# Altium schematic sheet Sensors-2.SchDoc(Sensors-2)
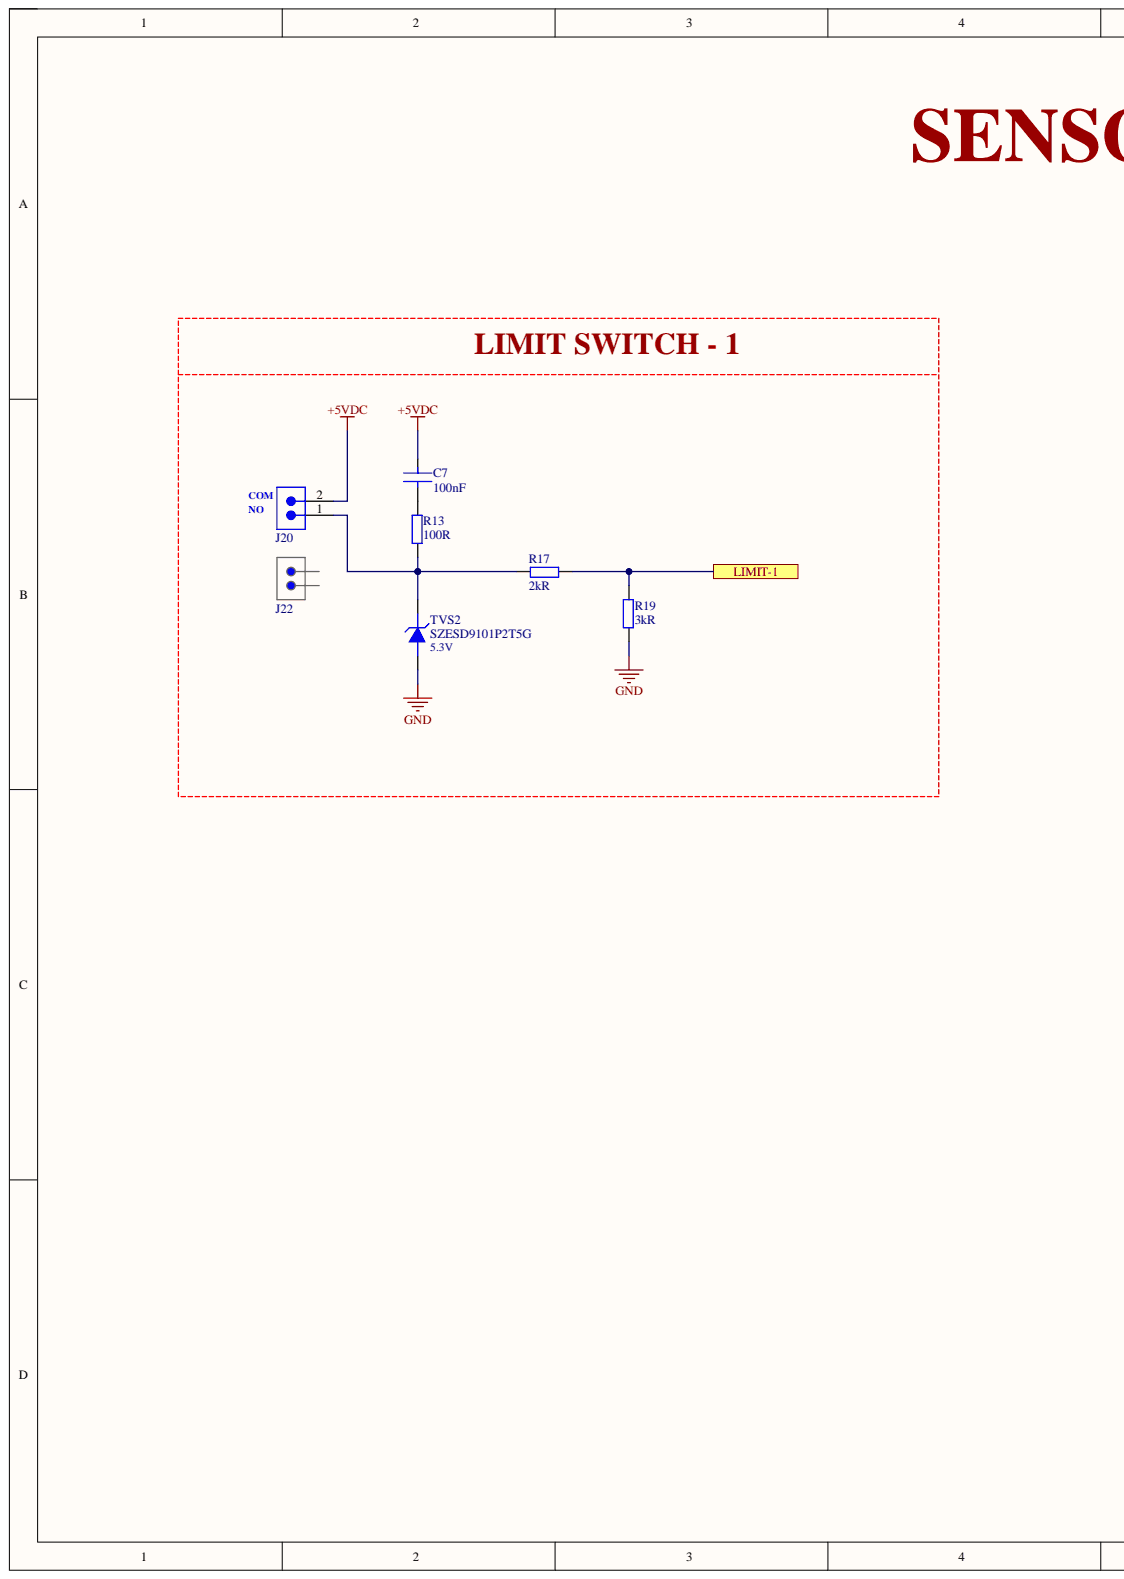
[diagram p0: Sensors-2.SchDoc, left]
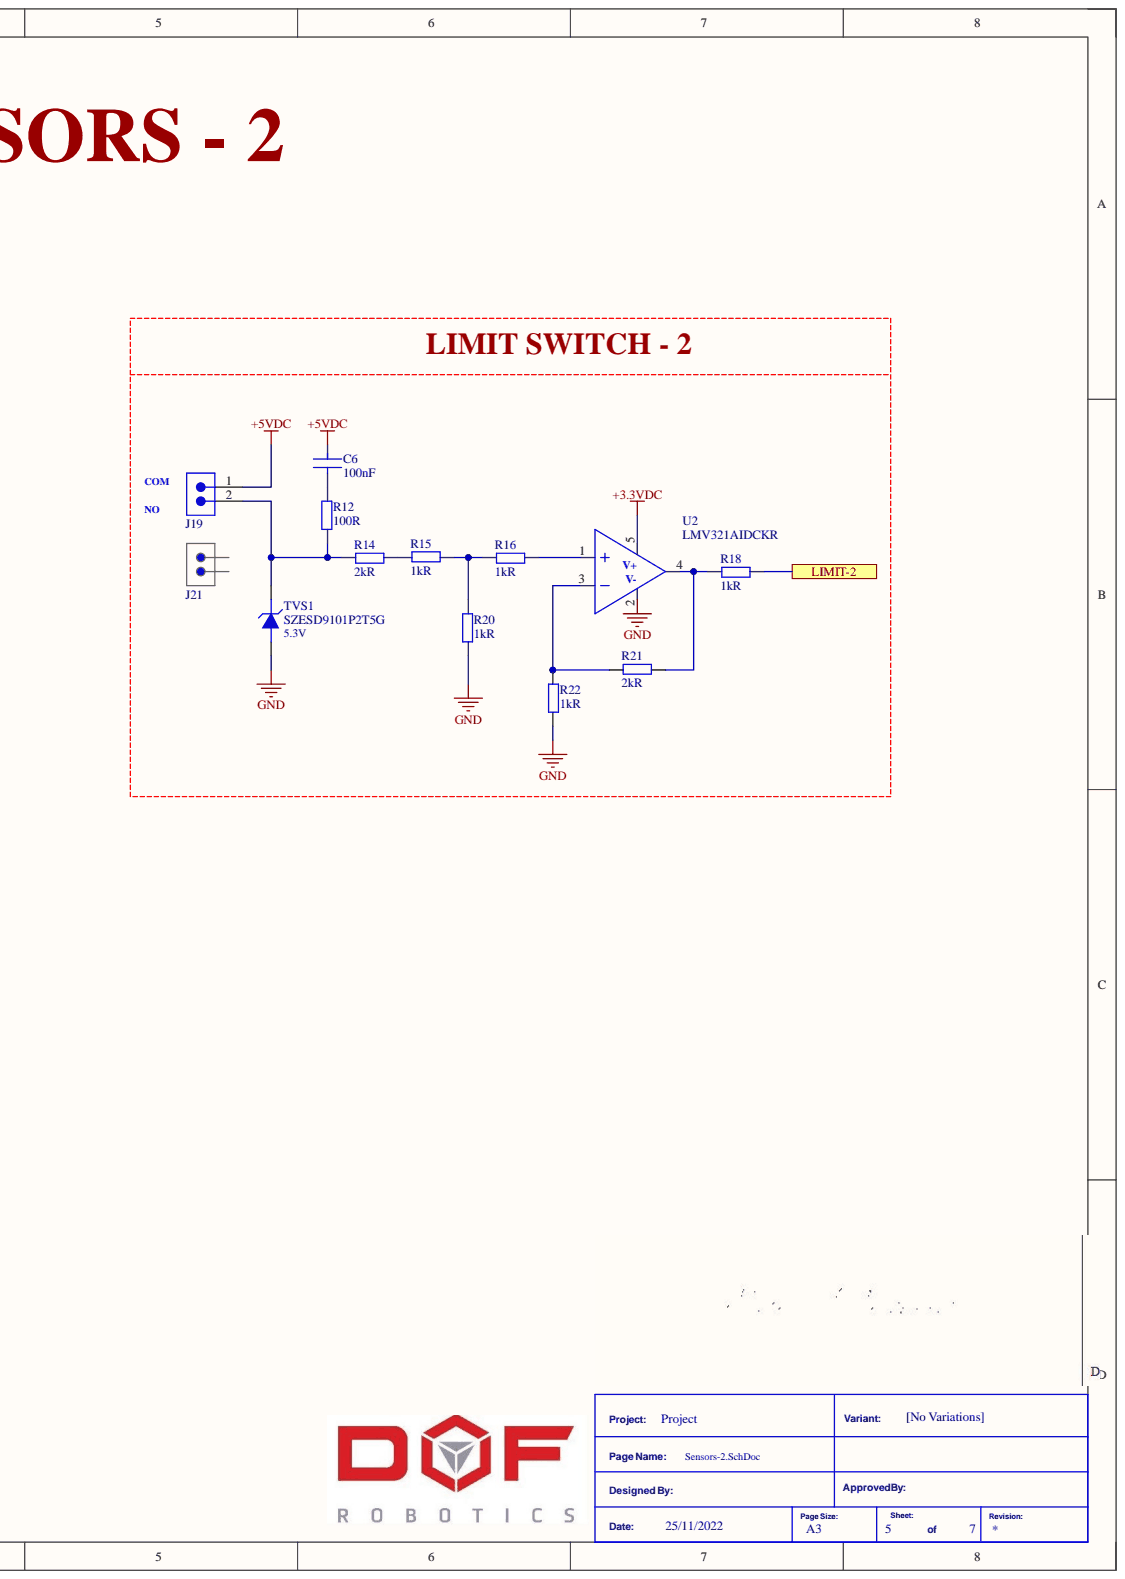
[diagram p1: Sensors-2.SchDoc, right]

## Parts
C6  Capacitance: 100nF  [CAP CER 0805]  (hidden comment: CL21B104KACNNNC)
C7  Capacitance: 100nF  [CAP CER 0805]  (hidden comment: CL21B104KACNNNC)
J19  691313710002  [CONN ML 1X2 WURTH 691313710002]  (value not drawn)
J20  691313710002  [CONN ML 1X2 WURTH 691313710002]  (value not drawn)
J21  691352710002  [CONN FML 1X2 WURTH 691352710002]  (value not drawn)
J22  691352710002  [CONN FML 1X2 WURTH 691352710002]  (value not drawn)
R12  Resistance: 100R  [RES 0805]  (hidden comment: ERJ-P06J101V)
R13  Resistance: 100R  [RES 0805]  (hidden comment: ERJ-P06J101V)
R14  Resistance: 2kR  [RES 0603]  (hidden comment: ERJ-3EKF2001V)
R15  Resistance: 1kR  [RES 0603]  (hidden comment: ERJ-PA3J102V)
R16  Resistance: 1kR  [RES 0603]  (hidden comment: ERJ-PA3J102V)
R17  Resistance: 2kR  [RES 0603]  (hidden comment: ERJ-3EKF2001V)
R18  Resistance: 1kR  [RES 0603]  (hidden comment: ERJ-PA3J102V)
R19  Resistance: 3kR  [RES 0603]  (hidden comment: AC0603FR-073KL)
R20  Resistance: 1kR  [RES 0603]  (hidden comment: ERJ-PA3J102V)
R21  Resistance: 2kR  [RES 0603]  (hidden comment: ERJ-3EKF2001V)
R22  Resistance: 1kR  [RES 0603]  (hidden comment: ERJ-PA3J102V)
TVS1  SZESD9101P2T5G  Min Breakdown Voltage: 5.3V  [SOD-923]
TVS2  SZESD9101P2T5G  Min Breakdown Voltage: 5.3V  [SOD-923]
U2  LMV321AIDCKR  [SC-70-5/SOT-353]

## Ports
LIMIT-1, LIMIT-2

## Nets
+3.3VDC: U2.5
+5VDC: C6.2 C7.2 J19.1 J20.2
GND: R19.1 R20.1 R22.2 TVS1.1 TVS2.1 U2.2
LIMIT-1: R17.1 R19.2 ; port LIMIT-1
LIMIT-2: R18.1 ; port LIMIT-2
NetC6_1: C6.1 R12.2
NetC7_1: C7.1 R13.2
NetJ19_2: J19.2 R12.1 R14.2 TVS1.2
NetJ20_1: J20.1 R13.1 R17.2 TVS2.2
NetR14_1: R14.1 R15.1
NetR15_2: R15.2 R16.2 R20.2
NetR16_1: R16.1 U2.1
NetR18_2: R18.2 R21.2 U2.4
NetR21_1: R21.1 R22.1 U2.3

## Library data of the parts
C6 C7 = CAP CER 0.1UF 25V X7R 0805: Manufacturer: Samsung; Tolerance: 10%; Case Code (Imperial): 0805; Case Code (Metric): 2012; Case/Package: 0805; Category: Ceramic Capacitors; Component Kind: Standard; ComponentLink1Description: https://datasheet.ciiva.com/25801/cl21b104kacnnnc-25801824.pdf?src-supplier=Digi-Key; ComponentLink1URL: https://datasheet.ciiva.com/25801/cl21b104kacnnnc-25801824.pdf?src-supplier=Digi-Key; ComponentLink2Description: https://datasheet.ciiva.com/15216/getdatasheetpartid-355204-15216236.pdf?src-supplier=Verical; ComponentLink2URL: https://datasheet.ciiva.com/15216/getdatasheetpartid-355204-15216236.pdf?src-supplier=Verical; Construction: Flat; Contact Plating: Tin; Depth: 1.25mm; Description: CAP CER 0.1UF 25V X7R 0805; Dielectric: X7R; Height: 0.85mm; Length: 2mm; Manufacturer Part Number: CL21B104KACNNNC; Max Operating Temperature: 125°C; Min Operating Temperature: -55°C; Mount: Surface Mount; Package Quantity: 1; Packaging: Tape and Reel; Pricing 1: 1=0.10000, 10=0.04000, 50=0.02200, 100=0.01790, 500=0.01282, 1000=0.01007 (USD); Radiation Hardening: No; RoHS: Yes; RoHS Compliant: Yes; Series: CL; Stock 1: 2617; Supplier 1: Digi-Key; Supplier Part Number 1: 1276-1099-1-ND; Thickness: 0.037inch; Voltage: 25V; Voltage Rating: 25V; Width: 0.049inch; Library Name: LibEnes.SchLib
J19 J20 = CONN ML 1X2 WURTH 691313710002: 5mm Pitch Right Angle Pluggable Term Block; PCB Header; Through Hole; 2 Way  (Mate to 691352710002); Manufacturer: Wurth Electronics; Connector Type: PCB,Terminal Block; Current: 20A; Number of Contacts: 2; Number of Levels: 1; Pitch: 0.197inch; Voltage: 300V; Category: Terminal Blocks; Color: Green; Component Kind: Standard; ComponentLink1Description: https://datasheet.ciiva.com/795/6913137100xx-795778.pdf?src-supplier=Digi-Key; ComponentLink1URL: https://datasheet.ciiva.com/795/6913137100xx-795778.pdf?src-supplier=Digi-Key; ComponentLink2Description: https://datasheet.ciiva.com/8043/1442229-8043531.pdf?src-supplier=Element14; ComponentLink2URL: https://datasheet.ciiva.com/8043/1442229-8043531.pdf?src-supplier=Element14; ComponentLink3Description: https://datasheet.ciiva.com/48692/001088691-da-01-en-wr-tbl-terminal-block3137-691313710002-48692902.pdf?src-supplier=Conrad; ComponentLink3URL: https://datasheet.ciiva.com/48692/001088691-da-01-en-wr-tbl-terminal-block3137-691313710002-48692902.pdf?src-supplier=Conrad; Contact Material: Brass; Height: 12mm; Length: 12.5mm; Manufacturer Part Number: 691313710002; Mount: Through Hole; Number of Positions Per Level: 2; Number of Rows: 1; Package Quantity: 600; Packaging: Bulk; Pricing 1: 1=0.44000, 10=0.39400, 50=0.34000, 100=0.30500, 250=0.28600, 600=0.26900 (USD); REACH SVHC: Unknown; RoHS: Yes; RoHS Compliant: Yes; Series: WR-TBL Series 3137; Stock 1: 2898; Supplier 1: Digi-Key; Supplier Part Number 1: 732-2799-ND; Termination: Solder; Width: 12mm; Library Name: LibEnes.SchLib
J21 J22 = CONN FML 1X2 WURTH 691352710002: Conn Terminal Block F 2 POS 5mm Screw RA Cable Mount 20A Box    (Mate to 691313710002); Manufacturer: Wurth Electronics; Current Rating: 12A; Number of Contacts: 2; Number of Levels: 1; Pitch: 0.197inch; Stripping Length: -7mm; Voltage: 300V; Wire Gauge (Max): 24AWG; Wire Gauge (Min): 12AWG; Category: Terminal Blocks; Color: Green; Component Kind: Standard; ComponentLink1Description: https://datasheet.ciiva.com/7992/1442233-7992379.pdf?src-supplier=Element14; ComponentLink1URL: https://datasheet.ciiva.com/7992/1442233-7992379.pdf?src-supplier=Element14; ComponentLink2Description: https://datasheet.ciiva.com/796/6913527100xx-796063.pdf?src-supplier=Digi-Key; ComponentLink2URL: https://datasheet.ciiva.com/796/6913527100xx-796063.pdf?src-supplier=Digi-Key; Contact Material: Brass; Current: 20A; Lead Free: Lead Free; Length: 18.2mm; Manufacturer Part Number: 691352710002; Mount: Free Hanging; Number of Positions Per Level: 2; Package Quantity: 350; Packaging: Bulk; REACH SVHC: Unknown; RoHS: Yes; RoHS Compliant: Yes; Series: WR-TBL Series 3527; Stock 1: 2782; Supplier 1: Digi-Key; Supplier Part Number 1: 732-2775-ND; Supplier Unit Price 1: 1=1.32000, 10=1.18200, 50=1.02200, 100=0.91400, 350=0.85900, 700=0.80500 (USD); Termination: Screw; Voltage Rating: 300V; Width: 10mm; Wire/Cable Gauge: 24AWG; Library Name: LibEnes.SchLib
R12 R13 = RES 100R 5% 0.5W 0805: Res Thick Film 0805 100 Ohm 5% 0.5W ±200ppm/°C Molded SMD Punched Carrier T/R; Manufacturer: Panasonic; Max Power Dissipation: 500mW; Voltage Rating: 400V; Voltage Rating (DC): 150V; Case Code (Imperial): 0805; Case Code (Metric): 2012; Case/Package: 0805; Category: Chip SMD Resistors; Component Kind: Standard; ComponentLink1Description: https://datasheet.ciiva.com/11810/0900766b812cb812-11810156.pdf?src-supplier=RSComponents; ComponentLink1URL: https://datasheet.ciiva.com/11810/0900766b812cb812-11810156.pdf?src-supplier=RSComponents; ComponentLink2Description: https://datasheet.ciiva.com/7831/aoa0000ce4-7831000.pdf?src-supplier=Element14; ComponentLink2URL: https://datasheet.ciiva.com/7831/aoa0000ce4-7831000.pdf?src-supplier=Element14; ComponentLink3Description: https://datasheet.ciiva.com/24163/aoa0000ce4-64075-24163318.pdf?src-supplier=Mouser; ComponentLink3URL: https://datasheet.ciiva.com/24163/aoa0000ce4-64075-24163318.pdf?src-supplier=Mouser; ComponentLink4Description: https://datasheet.ciiva.com/16788/70068906-16788678.pdf?src-supplier=Allied+Electronics; ComponentLink4URL: https://datasheet.ciiva.com/16788/70068906-16788678.pdf?src-supplier=Allied+Electronics; ComponentLink5Description: https://datasheet.ciiva.com/16767/70068906-16767564.pdf?src-supplier=Allied+Electronics; ComponentLink5URL: https://datasheet.ciiva.com/16767/70068906-16767564.pdf?src-supplier=Allied+Electronics; ComponentLink6Description: https://datasheet.ciiva.com/9186/aoa0000ce4-9186844.pdf?src-supplier=Avnet; ComponentLink6URL: https://datasheet.ciiva.com/9186/aoa0000ce4-9186844.pdf?src-supplier=Avnet; Construction: Rectangular; Contact Plating: Tin; Depth: 1.25mm; Features: Automotive AEC-Q200; Height: 0.6mm; Lead Free: Lead Free; Length: 2mm; Manufacturer Part Number: ERJ-P06J101V; Max Operating Temperature: 155°C; Military Standard: Not; Min Operating Temperature: -55°C; Mount: Surface Mount; Number of Terminations: 2; Package Quantity: 1; Packaging: Tape and Reel; Power Rating: 500mW; Pricing 1: 1=0.12000, 10=0.10600, 100=0.04070, 1000=0.01790, 2500=0.01546 (USD); REACH SVHC: Unknown; Resistance Tolerance: 5%; Resistor Type: Thick Film; RoHS: Yes; RoHS Compliant: Yes; Series: ERJ; Stock 1: 27836; Supplier 1: Digi-Key; Supplier Part Number 1: P100ADCT-ND; Technology: THICK FILM; Temperature Coefficient: 200ppm/°C; Termination: SMD/SMT; Tolerance: 5%; Weight: 0.000194oz; Width: 1.2mm; Library Name: LibEnes.SchLib
R14 R17 R21 = RES 2KR 1% 0.1W 0603: Res Thick Film 0603 2K Ohm 1% 1/10W ±100ppm/°C Molded SMD Punched Carrier T/R; Manufacturer: Panasonic; Max Power Dissipation: 100mW; Voltage Rating: 75V; Voltage Rating (DC): 50V; Case Code (Imperial): 0603; Case Code (Metric): 1608; Case/Package: 0603; Category: Chip SMD Resistors; Component Kind: Standard; ComponentLink1Description: https://datasheet.ciiva.com/43460/1678782-43460485.pdf?src-supplier=Farnell; ComponentLink1URL: https://datasheet.ciiva.com/43460/1678782-43460485.pdf?src-supplier=Farnell; ComponentLink2Description: https://datasheet.ciiva.com/6082/1678782-6082059.pdf?src-supplier=Farnell; ComponentLink2URL: https://datasheet.ciiva.com/6082/1678782-6082059.pdf?src-supplier=Farnell; ComponentLink3Description: https://datasheet.ciiva.com/46377/1678782-46377366.pdf?src-supplier=Element14; ComponentLink3URL: https://datasheet.ciiva.com/46377/1678782-46377366.pdf?src-supplier=Element14; ComponentLink4Description: https://datasheet.ciiva.com/28673/1678782-28673990.pdf?src-supplier=Element14; ComponentLink4URL: https://datasheet.ciiva.com/28673/1678782-28673990.pdf?src-supplier=Element14; ComponentLink5Description: https://datasheet.ciiva.com/24027/aoa0000ce2-24027453.pdf?src-supplier=Mouser; ComponentLink5URL: https://datasheet.ciiva.com/24027/aoa0000ce2-24027453.pdf?src-supplier=Mouser; ComponentLink6Description: https://datasheet.ciiva.com/16771/70260748-16771369.pdf?src-supplier=Allied+Electronics; ComponentLink6URL: https://datasheet.ciiva.com/16771/70260748-16771369.pdf?src-supplier=Allied+Electronics; ComponentLink7Description: https://datasheet.ciiva.com/16200/aoa0000ce2-16200501.pdf?src-supplier=Future+Electronics; ComponentLink7URL: https://datasheet.ciiva.com/16200/aoa0000ce2-16200501.pdf?src-supplier=Future+Electronics; ComponentLink8Description: https://datasheet.ciiva.com/30064/aoa0000ce2-30064920.pdf?src-supplier=Onlinecomponents.com; ComponentLink8URL: https://datasheet.ciiva.com/30064/aoa0000ce2-30064920.pdf?src-supplier=Onlinecomponents.com; Construction: Rectangular; Contact Plating: Tin; Depth: 0.8mm; Features: Automotive AEC-Q200; Height: 0.022inch; Lead Free: Lead Free; Length: 1.6mm; Manufacturer Part Number: ERJ-3EKF2001V; Max Operating Temperature: 155°C; Military Standard: Not; Min Operating Temperature: -55°C; Mount: Surface Mount; Number of Terminations: 2; Package Quantity: 1; Packaging: Tape and Reel; Power Rating: 100mW; Pricing 1: 1=0.10000, 10=0.04800, 100=0.01930, 1000=0.00868, 2500=0.00754 (USD); Radiation Hardening: No; REACH SVHC: No SVHC; Resistance Tolerance: 1%; Resistor Type: Thick Film; RoHS: Yes; RoHS Compliant: Yes; Series: ERJ; Size Code: 0603; Stock 1: 1368069; Supplier 1: Digi-Key; Supplier Part Number 1: P2.00KHCT-ND; Temperature Coefficient: 100ppm/°C; Termination: SMD/SMT; Tolerance: 1%; Width: 0.031inch; Library Name: LibEnes.SchLib
R15 R16 R18 R20 R22 = RES 1KR 5% 0.25W 0603: Res Thick Film 0603 1K Ohm 5% 0.25W(1/4W) ±200ppm/C Pad SMD Automotive T/R; Manufacturer: Panasonic; Max Power Dissipation: 250mW; Temperature Coefficient: 200ppm/°C; Case Code (Imperial): 0603; Case Code (Metric): 1608; Case/Package: 0603; Category: Chip SMD Resistors; Component Kind: Standard; ComponentLink1Description: https://industrial.panasonic.com/ww/system/files/data/download/catalog/id_fixed-resistors_e_1.pdf?src-supplier=Digi-Key; ComponentLink1URL: https://industrial.panasonic.com/ww/system/files/data/download/catalog/id_fixed-resistors_e_1.pdf?src-supplier=Digi-Key; Features: Automotive AEC-Q200; Height: 0.018inch; Length: 0.063inch; Manufacturer Part Number: ERJ-PA3J102V; Max Operating Temperature: 155°C; Min Operating Temperature: -55°C; Number of Terminations: 2; Packaging: Tape and Reel; Pricing 1: 1=0.11000, 10=0.09600, 100=0.03710, 1000=0.01631, 2500=0.01408 (USD); Resistor Type: Thick Film; RoHS: Yes; Stock 1: 25628; Supplier 1: Digi-Key; Supplier Part Number 1: P1KBZCT-ND; Tolerance: 5%; Width: 0.031inch; Library Name: LibEnes.SchLib
R19 = RES 3KR 1% 0.1W 0603: Res Thick Film 0603 3K Ohm 1% 0.1W(1/10W) ±100ppm/C Pad SMD Automotive T/R; Manufacturer: Yageo; Max Power Dissipation: 100mW; Temperature Coefficient: 100ppm/°C; Voltage Rating: 50V; Case Code (Imperial): 0603; Case Code (Metric): 1608; Case/Package: 0603; Category: Chip SMD Resistors; Component Kind: Standard; ComponentLink1Description: http://www.mouser.com/ds/2/447/yageodocoutput-1111992.pdf?src-supplier=Mouser; ComponentLink1URL: http://www.mouser.com/ds/2/447/yageodocoutput-1111992.pdf?src-supplier=Mouser; ComponentLink2Description: http://www.yageo.com/NewPortal/yageodocoutput?fileName=/pdf/R-Chip/PYu-AC_51_RoHS_L_6.pdf&src-supplier=Digi-Key; ComponentLink2URL: http://www.yageo.com/NewPortal/yageodocoutput?fileName=/pdf/R-Chip/PYu-AC_51_RoHS_L_6.pdf&src-supplier=Digi-Key; ComponentLink3Description: https://www.yageo.com/upload/media/product/productsearch/datasheet/rchip/PYu-AC_51_RoHS_L_7.pdf?src-supplier=Digi-Key; ComponentLink3URL: https://www.yageo.com/upload/media/product/productsearch/datasheet/rchip/PYu-AC_51_RoHS_L_7.pdf?src-supplier=Digi-Key; Features: Moisture Resistant,Automotive AEC-Q200; Height: 0.022inch; Lead Free: Lead Free; Length: 0.063inch; Manufacturer Part Number: AC0603FR-073KL; Max Operating Temperature: 155°C; Min Operating Temperature: -55°C; Number of Terminations: 2; Packaging: Tape and Reel; Power Rating: 100mW; Pricing 1: 1=0.10000, 10=0.02600, 100=0.01050, 1000=0.00472, 2500=0.00410 (USD); Resistor Type: Thick Film; RoHS: Yes; RoHS Compliant: Yes; Series: AC; Stock 1: 127930; Supplier 1: Digi-Key; Supplier Part Number 1: YAG3607CT-ND; Termination: SMD/SMT; Tolerance: 1%; Width: 0.031inch; Library Name: LibEnes.SchLib
TVS1 TVS2 = TVS 5.3V SZESD9101P2T5G: Low Capacitance ESD Protection Diodes for High Speed Data Lines; Manufacturer: ON Semiconductor; Clamping Voltage: 7V; Number of Unidirectional Channels: 1; Peak Pulse Current: 16A; Power Line Protection: No; Reverse Standoff Voltage: 5V; Case/Package: SOD-923; Category: ESD and Circuit Protection ICs; Component Kind: Standard; ComponentLink1Description: http://www.farnell.com/datasheets/2290214.pdf?src-supplier=Farnell; ComponentLink1URL: http://www.farnell.com/datasheets/2290214.pdf?src-supplier=Farnell; ComponentLink2Description: http://www.onsemi.com/pub/Collateral/ESD9101-D.PDF?src-supplier=Digi-Key; ComponentLink2URL: http://www.onsemi.com/pub/Collateral/ESD9101-D.PDF?src-supplier=Digi-Key; Manufacturer Part Number: SZESD9101P2T5G; Max Operating Temperature: 150°C; Min Operating Temperature: -55°C; Mount: Surface Mount; Packaging: Tape and Reel; Pricing 1: 1=0.49000, 10=0.36700, 100=0.20790, 500=0.13770, 1000=0.10557, 2000=0.09180 (USD); Resistor Type: Zener; RoHS: Yes; Stock 1: 109090; Supplier 1: Digi-Key; Supplier Part Number 1: SZESD9101P2T5GOSCT-ND; Library Name: LibEnes.SchLib
U2 = OPAMP LMV321AIDCKR: Single Low-Voltage, Cost-Optimized Rail-to-Rail Output Operational Amplifier 5-SC70 -40 to 125; Manufacturer: Texas Instruments; Number of Channels: 1; Case/Package: SC70-5/SOT-353; Category: Amplifiers - Op Amps, Buffer, Instrumentation; Component Kind: Standard; Manufacturer Part Number: LMV321AIDCKR; Max Operating Temperature: 125°C; Min Operating Temperature: -40°C; Mount: Surface Mount; Pricing 1: 1=0.47000, 10=0.40500, 25=0.37840, 100=0.30270, 250=0.28112, 500=0.23786, 1000=0.18380 (USD); RoHS: Yes; RoHS Compliant: Yes; Stock 1: 15678; Supplier 1: Digi-Key; Supplier Part Number 1: 296-53221-1-ND; Library Name: LibEnes.SchLib
